# Altium schematic sheet OGT_SD.SchDoc
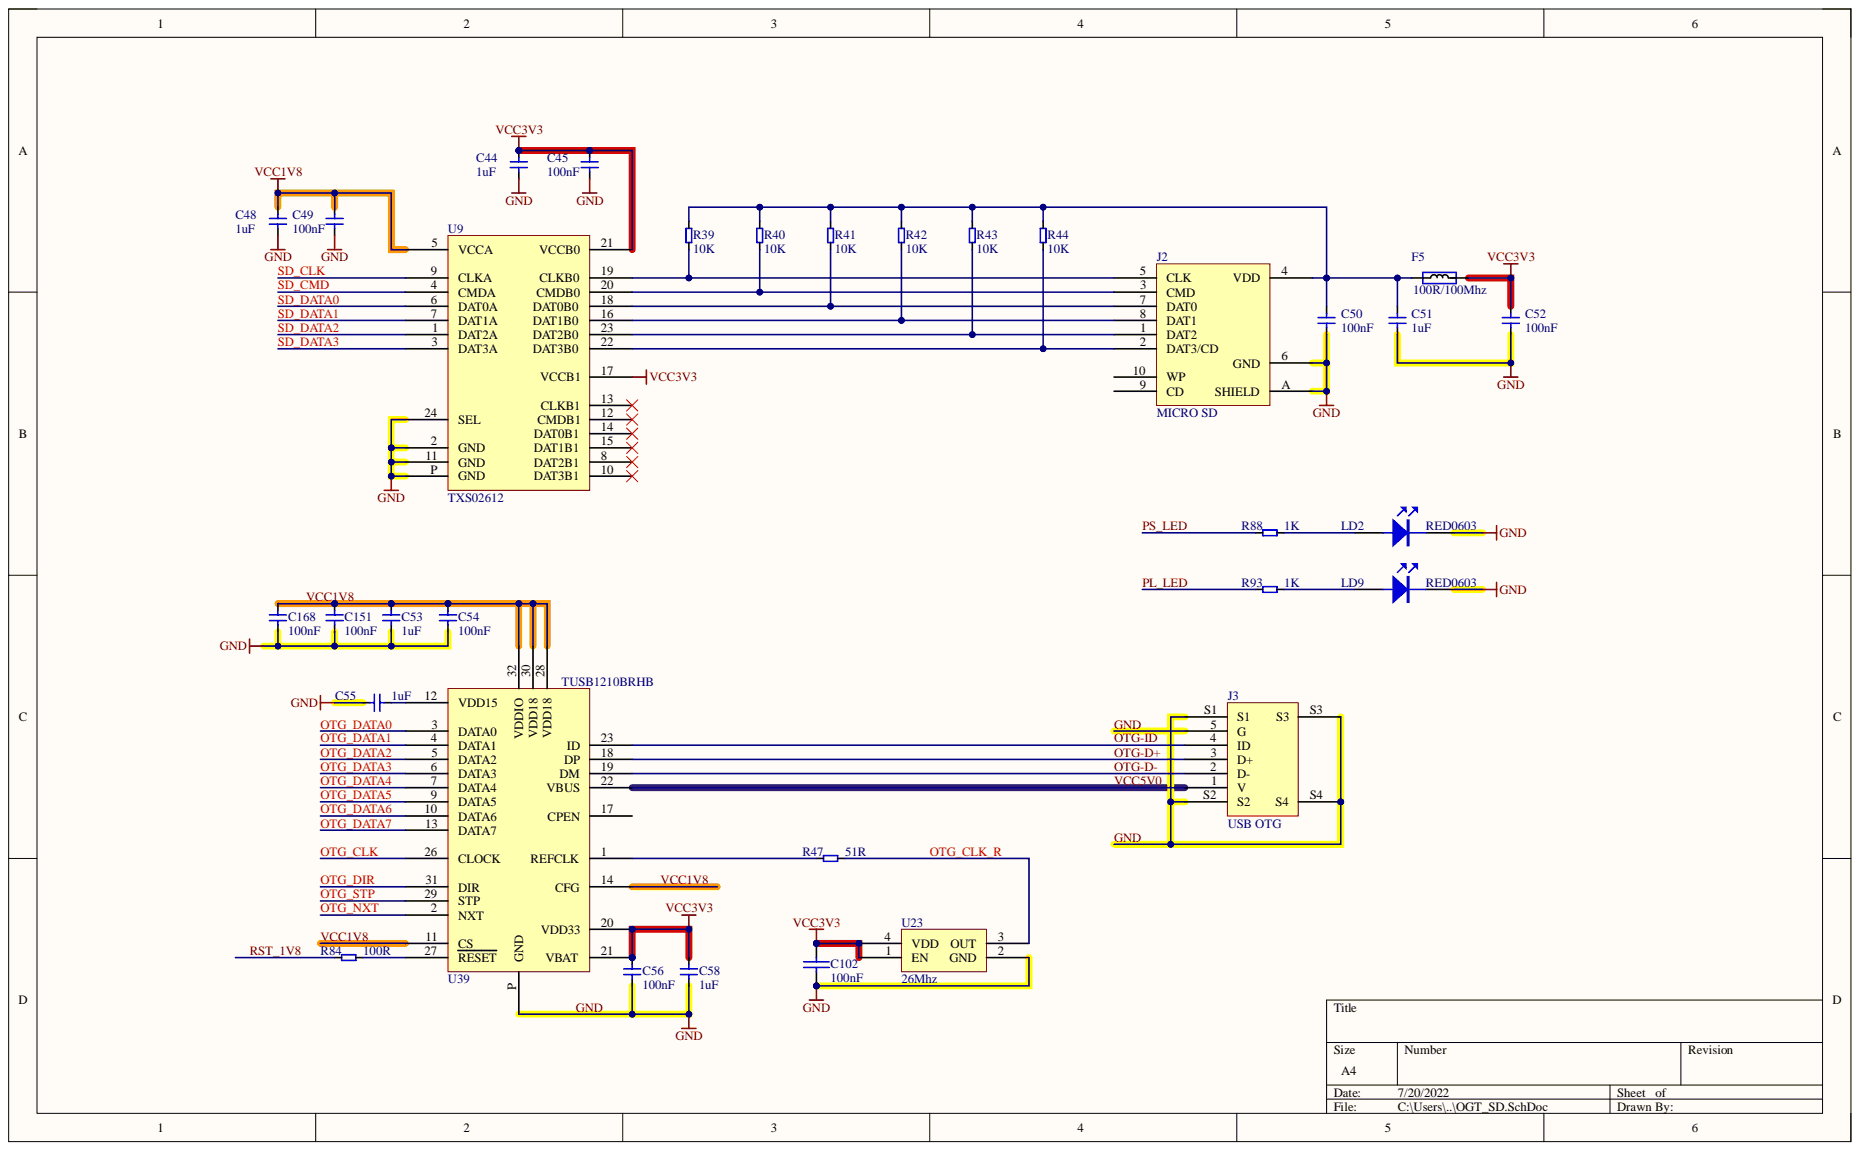
[diagram p0: OGT_SD.SchDoc]

## Parts
C44  1uF  [C0402]
C45  100nF  [C0201]
C48  1uF  [C0402]
C49  100nF  [C0201]
C50  100nF  [C0201]
C51  1uF  [C0402]
C52  100nF  [C0201]
C53  1uF  [C0402]
C54  100nF  [C0201]
C55  1uF  [C0201]
C56  100nF  [C0201]
C58  1uF  [C0402]
C102  100nF  [C0201]
C151  100nF  [C0201]
C168  100nF  [C0201]
F5  100R/100Mhz  [L0402]
J2  MICRO SD  [TF_NEW]
J3  USB OTG  [USB_5P_F_B_RA_HOLE]
LD2  RED0603  [LED0402]
LD9  RED0603  [LED0402]
R39  10K  [R0201]
R40  10K  [R0201]
R41  10K  [R0201]
R42  10K  [R0201]
R43  10K  [R0201]
R44  10K  [R0201]
R47  51R  [R0201]
R84  100R  [R0201]
R88  1K  [R0201]
R93  1K  [R0201]
U9  TXS02612  [QFN24]
U23  26Mhz  [OSC_3225]
U39  TUSB1210BRHB  [QFN32-C]

## Nets
VCC3V3: C44.1 C45.1 C52.1 C56.2 C58.2 C102.1 F5.2 U9.17(VCCB1) U9.21(VCCB0) U23.1(EN) U23.4(VDD) U39.20(VDD33) U39.21(VBAT)
GND: C44.2 C45.2 C48.2 C49.2 C50.2 C51.2 C52.2 C53.1 C54.1 C55.1 C56.1 C58.1 C102.2 C151.1 C168.1 J2.6(GND) J2.A(SHIELD) J3.5(G) J3.S1 J3.S2 J3.S3 J3.S4 LD2.1 LD9.1 U9.2(GND) U9.11(GND) U9.24(SEL) U9.P(GND) U23.2(GND) U39.P(GND)
OTG-D+: J3.3(D+) U39.18(DP)
OTG-D-: J3.2(D-) U39.19(DM)
OTG-ID: J3.4(ID) U39.23(ID)
OTG_CLK: U39.26(CLOCK)
OTG_CLK_R: R47.1 U23.3(OUT)
OTG_DATA0: U39.3(DATA0)
OTG_DATA1: U39.4(DATA1)
OTG_DATA2: U39.5(DATA2)
OTG_DATA3: U39.6(DATA3)
OTG_DATA4: U39.7(DATA4)
OTG_DATA5: U39.9(DATA5)
OTG_DATA6: U39.10(DATA6)
OTG_DATA7: U39.13(DATA7)
OTG_DIR: U39.31(DIR)
OTG_NXT: U39.2(NXT)
OTG_STP: U39.29(STP)
PL_LED: R93.2
PS_LED: R88.2
RST_1V8: R84.2
SD_CLK: U9.9(CLKA)
SD_CMD: U9.4(CMDA)
SD_DATA0: U9.6(DAT0A)
SD_DATA1: U9.7(DAT1A)
SD_DATA2: U9.1(DAT2A)
SD_DATA3: U9.3(DAT3A)
VCC1V8: C48.1 C49.1 C53.2 C54.2 C151.2 C168.2 U9.5(VCCA) U39.11(CS) U39.14(CFG) U39.28(VDD18) U39.30(VDD18) U39.32(VDDIO)
VCC5V0: J3.1(V) U39.22(VBUS)
NetC50_1: C50.1 C51.1 F5.1 J2.4(VDD) R39.2 R40.2 R41.2 R42.2 R43.2 R44.2
NetC55_2: C55.2 U39.12(VDD15)
NetJ2_1: J2.1(DAT2) R43.1 U9.23(DAT2B0)
NetJ2_2: J2.2(DAT3/CD) R44.1 U9.22(DAT3B0)
NetJ2_3: J2.3(CMD) R40.1 U9.20(CMDB0)
NetJ2_5: J2.5(CLK) R39.1 U9.19(CLKB0)
NetJ2_7: J2.7(DAT0) R41.1 U9.18(DAT0B0)
NetJ2_8: J2.8(DAT1) R42.1 U9.16(DAT1B0)
NetLD2_2: LD2.2 R88.1
NetLD9_2: LD9.2 R93.1
NetR47_2: R47.2 U39.1(REFCLK)
NetR84_1: R84.1 U39.27(RESET)

Not connected: J2 9(CD) 10(WP); U9 8(DAT2B1) 10(DAT3B1) 12(CMDB1) 13(CLKB1) 14(DAT0B1) 15(DAT1B1); U39 17(CPEN)

## Library data of the parts
C44 C45 C48-C56 C58 C151 C168 = C: Capacitor; Component Kind: Standard; Library Name: 电容-SCH.LIB
C102 = CAP: Capacitor; Component Kind: Standard
F5 = Ferrite: Manufacturer: TDK Corporation; Manufacturer Part Number 1: MPZ2012S601AT000; Part Description: FERRITE BEAD 600 OHM 0805 1LN; Current Rating: 2A; DC Resistance (DCR): 100 mOhm Max; Filter Type: Differential Mode - Single; Impedance @ Frequency: 600 Ohm @ 100MHz; Value: 600R, 2A; Category: Filters; Component Kind: Standard; Height (Max): 0.041" (1.05mm); Mounting Type: Surface Mount; Package / Case: 0805 (2012 Metric); Packaging: Tape & Reel (TR); RoHS: RoHS Compliant; Size / Dimension: 0.079" L x 0.049" W (2.00mm x 1.25mm); Supplier 1: Digi-Key; Supplier Part Number 1: 445-2206-2-ND; Library Name: LimeMicroAltiumLib_schLib.SchLib
J3 = 1981584-1: Component Kind: Standard
LD2 LD9 = LED_12: Component Kind: Standard; Library Name: zc706_2_0.SCHLIB
R39-R44 R47 R84 R88 R93 = RES2: Component Kind: Standard; Library Name: 电阻-SCH.LIB
U23 = 767-100-136: 3.3V有源4P贴片晶振3225; Component Kind: Standard
J2 = MICRO SD: Component Kind: Standard; Library Name: Schlib1.SchLib
U9 = TXS02612: Component Kind: Standard; Library Name: Schlib1.SchLib
U39 = TUSB1210BRHB: Component Kind: Standard
